# Altium schematic sheet P02_YT8531.SchDoc(P02_YT8531)
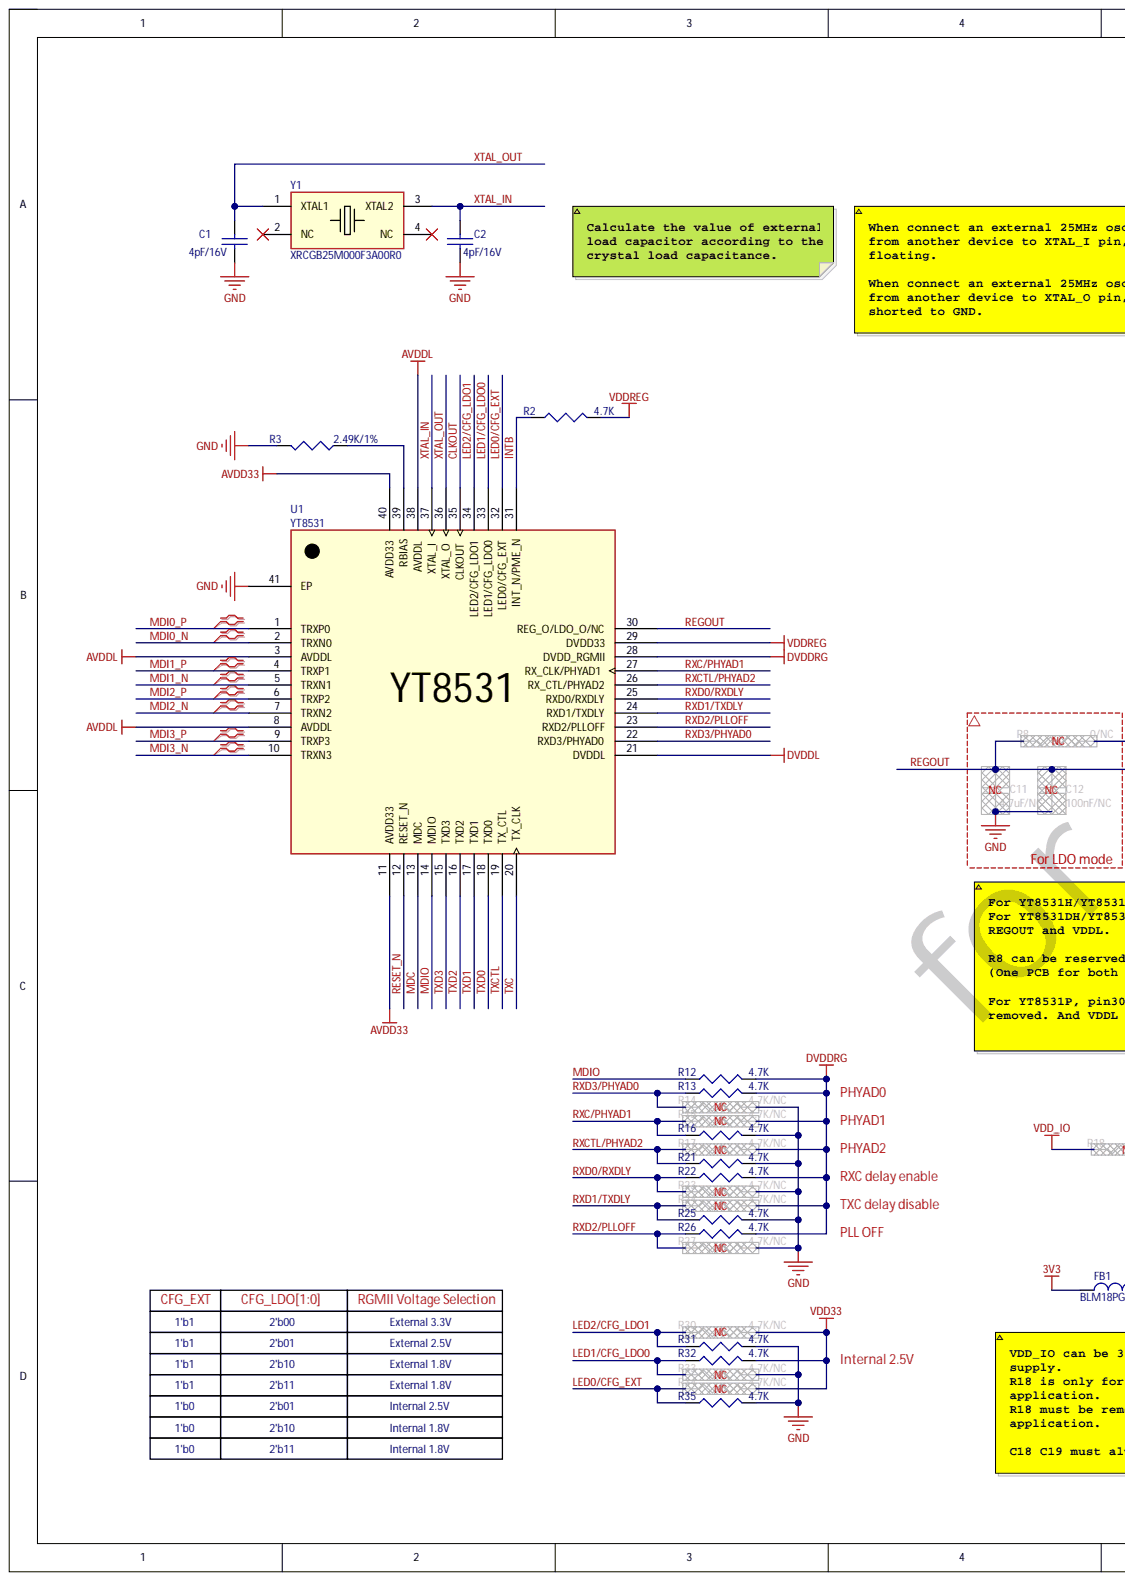
[diagram p0: P02_YT8531.SchDoc, left]
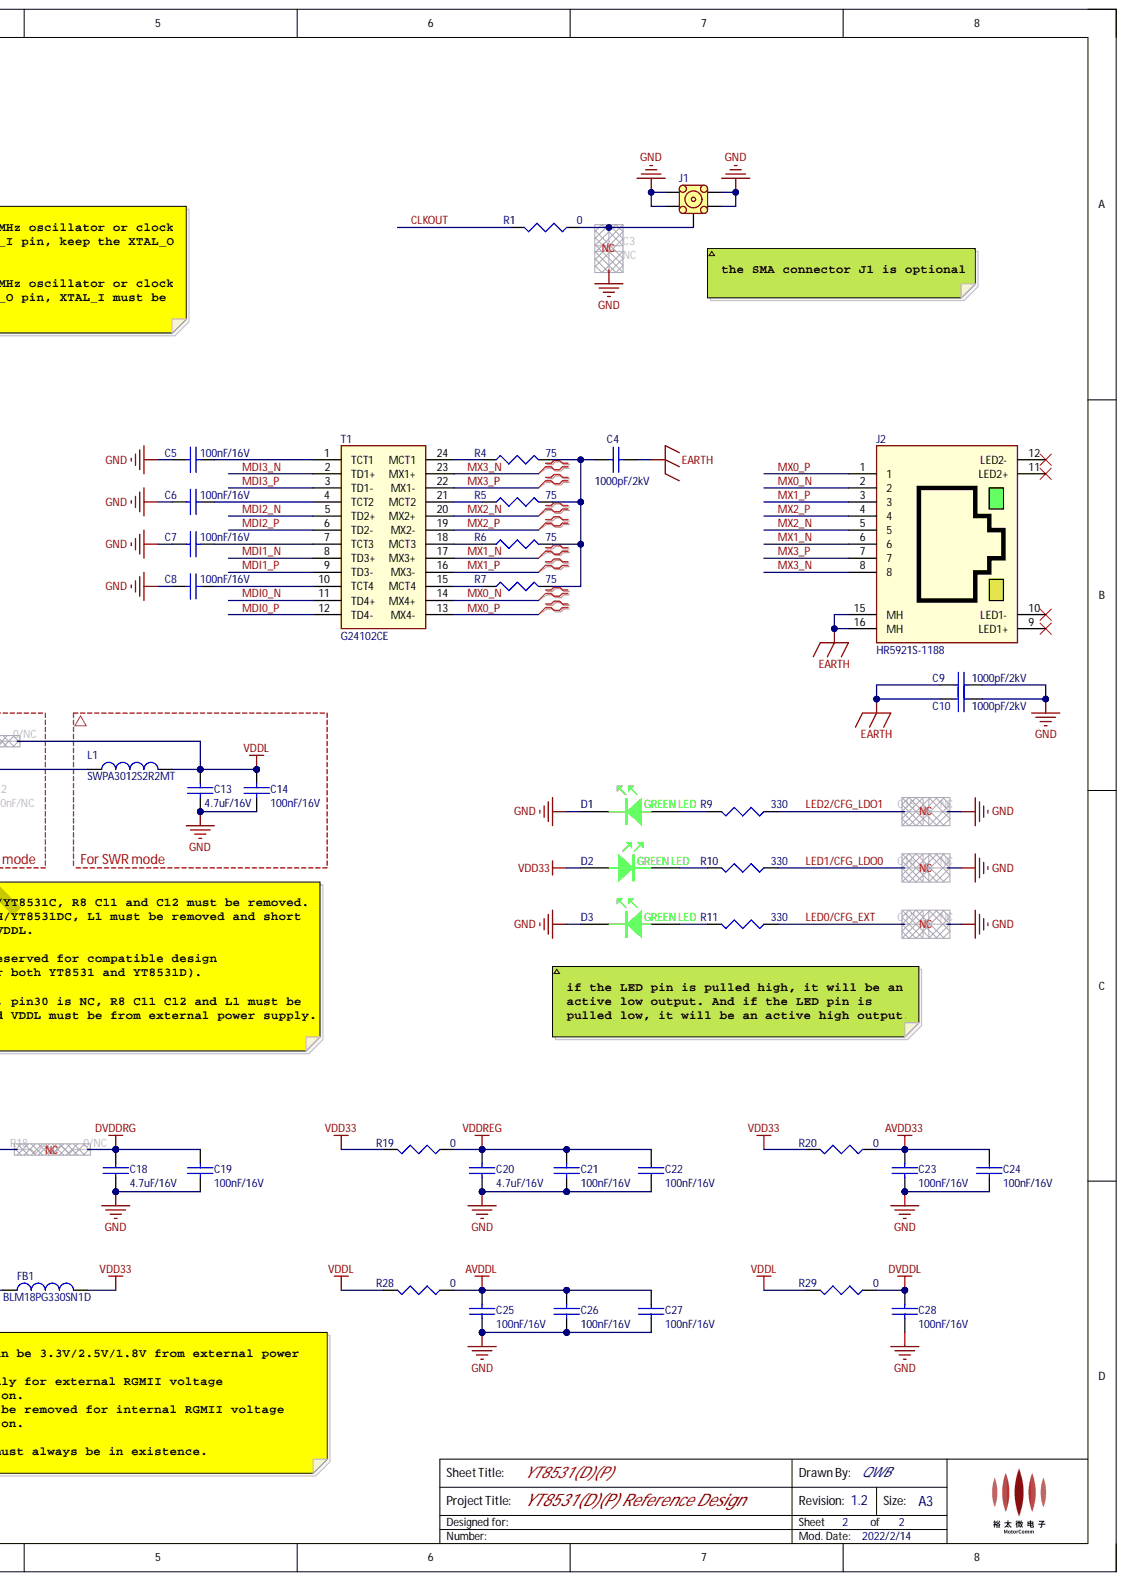
[diagram p1: P02_YT8531.SchDoc, right]

## Parts
C1  4pF/16V  [0402C]
C2  4pF/16V  [0402C]
C3  NC  [0402C]
C4  1000pF/2kV  [1206C]
C5  100nF/16V  [0402C]
C6  100nF/16V  [0402C]
C7  100nF/16V  [0402C]
C8  100nF/16V  [0402C]
C9  1000pF/2kV  [1206C]
C10  1000pF/2kV  [1206C]
C11  4.7uF/NC  [0603C]
C12  100nF/NC  [0402C]
C13  4.7uF/16V  [0603C]
C14  100nF/16V  [0402C]
C15  NC  [0402C]
C16  NC  [0402C]
C17  NC  [0402C]
C18  4.7uF/16V  [0603C]
C19  100nF/16V  [0402C]
C20  4.7uF/16V  [0603C]
C21  100nF/16V  [0402C]
C22  100nF/16V  [0402C]
C23  100nF/16V  [0402C]
C24  100nF/16V  [0402C]
C25  100nF/16V  [0402C]
C26  100nF/16V  [0402C]
C27  100nF/16V  [0402C]
C28  100nF/16V  [0402C]
D1  GREEN LED  [0603LED]
D2  GREEN LED  [0603LED]
D3  GREEN LED  [0603LED]
FB1  BLM18PG330SN1D  [0603I]
J1  5-1814832-2  [SMA_SOCKET]  (value not drawn)
J2  HR5921S-1188  [HR5921S]
L1  SWPA3012S2R2MT  [3012I]
R1  0  [0402R]
R2  4.7K  [0402R]
R3  2.49K/1%  [0402R]
R4  75  [0402R]
R5  75  [0402R]
R6  75  [0402R]
R7  75  [0402R]
R8  0/NC  [0402R]
R9  330  [0402R]
R10  330  [0402R]
R11  330  [0402R]
R12  4.7K  [0402R]
R13  4.7K  [0402R]
R14  4.7K/NC  [0402R]
R15  4.7K/NC  [0402R]
R16  4.7K  [0402R]
R17  4.7K/NC  [0402R]
R18  0/NC  [0402R]
R19  0  [0402R]
R20  0  [0402R]
R21  4.7K  [0402R]
R22  4.7K  [0402R]
R23  4.7K/NC  [0402R]
R24  4.7K/NC  [0402R]
R25  4.7K  [0402R]
R26  4.7K  [0402R]
R27  4.7K/NC  [0402R]
R28  0  [0402R]
R29  0  [0402R]
R30  4.7K/NC  [0402R]
R31  4.7K  [0402R]
R32  4.7K  [0402R]
R33  4.7K/NC  [0402R]
R34  4.7K/NC  [0402R]
R35  4.7K  [0402R]
T1  G24102CE  [H5004]
U1  YT8531  [QFN40_YT8531]
Y1  XRCGB25M000F3A00R0  [CYR-2016]

## Nets
3V3: FB1.1
AVDD33: C23.2 C24.2 R20.1 U1.11 U1.40
AVDDL: C25.2 C26.2 C27.2 R28.1 U1.3 U1.8 U1.38
DVDDL: C28.2 R29.1 U1.21
DVDDRG: C18.2 C19.2 R12.2 R13.2 R15.2 R17.2 R18.1 R22.2 R24.2 R26.2 U1.28
EARTH: C4.1 C9.2 C10.2 J2.15 J2.16
GND: C1.1 C2.1 C3.1 C5.2 C6.2 C7.2 C8.2 C9.1 C10.1 C11.1 C12.1 C13.1 C14.1 C15.1 C16.1 C17.1 C18.1 C19.1 C20.1 C21.1 C22.1 C23.1 C24.1 C25.1 C26.1 C27.1 C28.1 D1.2 D3.2 J1.1 J1.2 J1.4 J1.5 R3.2 R14.2 R16.2 R21.2 R23.2 R25.2 R27.2 R31.2 R33.2 R35.2 U1.41
VDD33: D2.1 FB1.2 R19.2 R20.2 R30.2 R32.2 R34.2
VDD_IO: R18.2
VDDL: C13.2 C14.2 L1.2 R8.1 R28.2 R29.2
VDDREG: C20.2 C21.2 C22.2 R2.2 R19.1 U1.29
CLKOUT: R1.2 U1.35
INTB: R2.1 U1.31
LED0/CFG_EXT: C17.2 R11.1 R34.1 R35.1 U1.32
LED1/CFG_LDO0: C16.2 R10.1 R32.1 R33.1 U1.33
LED2/CFG_LDO1: C15.2 R9.1 R30.1 R31.1 U1.34
MDC: U1.13
MDI0_N: T1.11 U1.2
MDI0_P: T1.12 U1.1
MDI1_N: T1.8 U1.5
MDI1_P: T1.9 U1.4
MDI2_N: T1.5 U1.7
MDI2_P: T1.6 U1.6
MDI3_N: T1.2 U1.10
MDI3_P: T1.3 U1.9
MDIO: R12.1 U1.14
MX0_N: J2.2 T1.14
MX0_P: J2.1 T1.13
MX1_N: J2.6 T1.17
MX1_P: J2.3 T1.16
MX2_N: J2.5 T1.20
MX2_P: J2.4 T1.19
MX3_N: J2.8 T1.23
MX3_P: J2.7 T1.22
REGOUT: C11.2 C12.2 L1.1 R8.2 U1.30
RESET_N: U1.12
RXC/PHYAD1: R15.1 R16.1 U1.27
RXCTL/PHYAD2: R17.1 R21.1 U1.26
RXD0/RXDLY: R22.1 R23.1 U1.25
RXD1/TXDLY: R24.1 R25.1 U1.24
RXD2/PLLOFF: R26.1 R27.1 U1.23
RXD3/PHYAD0: R13.1 R14.1 U1.22
TXC: U1.20
TXCTL: U1.19
TXD0: U1.18
TXD1: U1.17
TXD2: U1.16
TXD3: U1.15
XTAL_IN: C2.2 U1.37 Y1.3
XTAL_OUT: C1.2 U1.36 Y1.1
NetC3_2: C3.2 J1.3 R1.1
NetC4_2: C4.2 R4.2 R5.2 R6.2 R7.2
NetC5_1: C5.1 T1.1
NetC6_1: C6.1 T1.4
NetC7_1: C7.1 T1.7
NetC8_1: C8.1 T1.10
NetD1_1: D1.1 R9.2
NetD2_2: D2.2 R10.2
NetD3_1: D3.1 R11.2
NetR3_1: R3.1 U1.39
NetR4_1: R4.1 T1.24
NetR5_1: R5.1 T1.21
NetR6_1: R6.1 T1.18
NetR7_1: R7.1 T1.15

Not connected: J2 9 10 11 12; Y1 2 4

## Library data of the parts
C1-C17 C19 C21-C28 = CAP: Capacitor; Component Kind: Standard; Library Name: PHY_to_PHY.SchLib
C18 C20 = CAP: 10uF/16V; Component Kind: Standard; Library Name: PHY_to_PHY.SchLib
D1-D3 = GREEN LED: Diode; Component Kind: Standard; Library Name: motor_comm_Library.IntLib
FB1 = BLM18PG330SN1D: Ferrite Beads; Component Kind: Standard; Library Name: PHY_to_PHY.SchLib
J1 = SMA connector: Component Kind: Standard; Description: SMA connector; Library Name: motor_comm.SchLib
J2 = HR5921S-1188: RJ45 with LED no Transformer 10/100Base-T; Component Kind: Standard; Library Name: motor_comm_Library.IntLib
L1 = Inductor: Component Kind: Standard; Description: Inductor; Library Name: motor_comm_Library.IntLib
R1-R35 = RES1: Resistor; Component Kind: Standard; Library Name: PHY_to_PHY.SchLib
T1 = HX5004NL: single port transformer; Component Kind: Standard; Library Name: motor_comm_Library.IntLib
U1 = YT8531_B_version: 10/100/1000Mbps Ethernet Precision Transceiver; Component Kind: Standard; Library Name: motor_comm.SchLib
Y1 = CRYSTAL_2016: Component Kind: Standard; Library Name: motor_comm.SchLib
